# Altium schematic sheet 4.BMI055.SchDoc(4.BMI055)
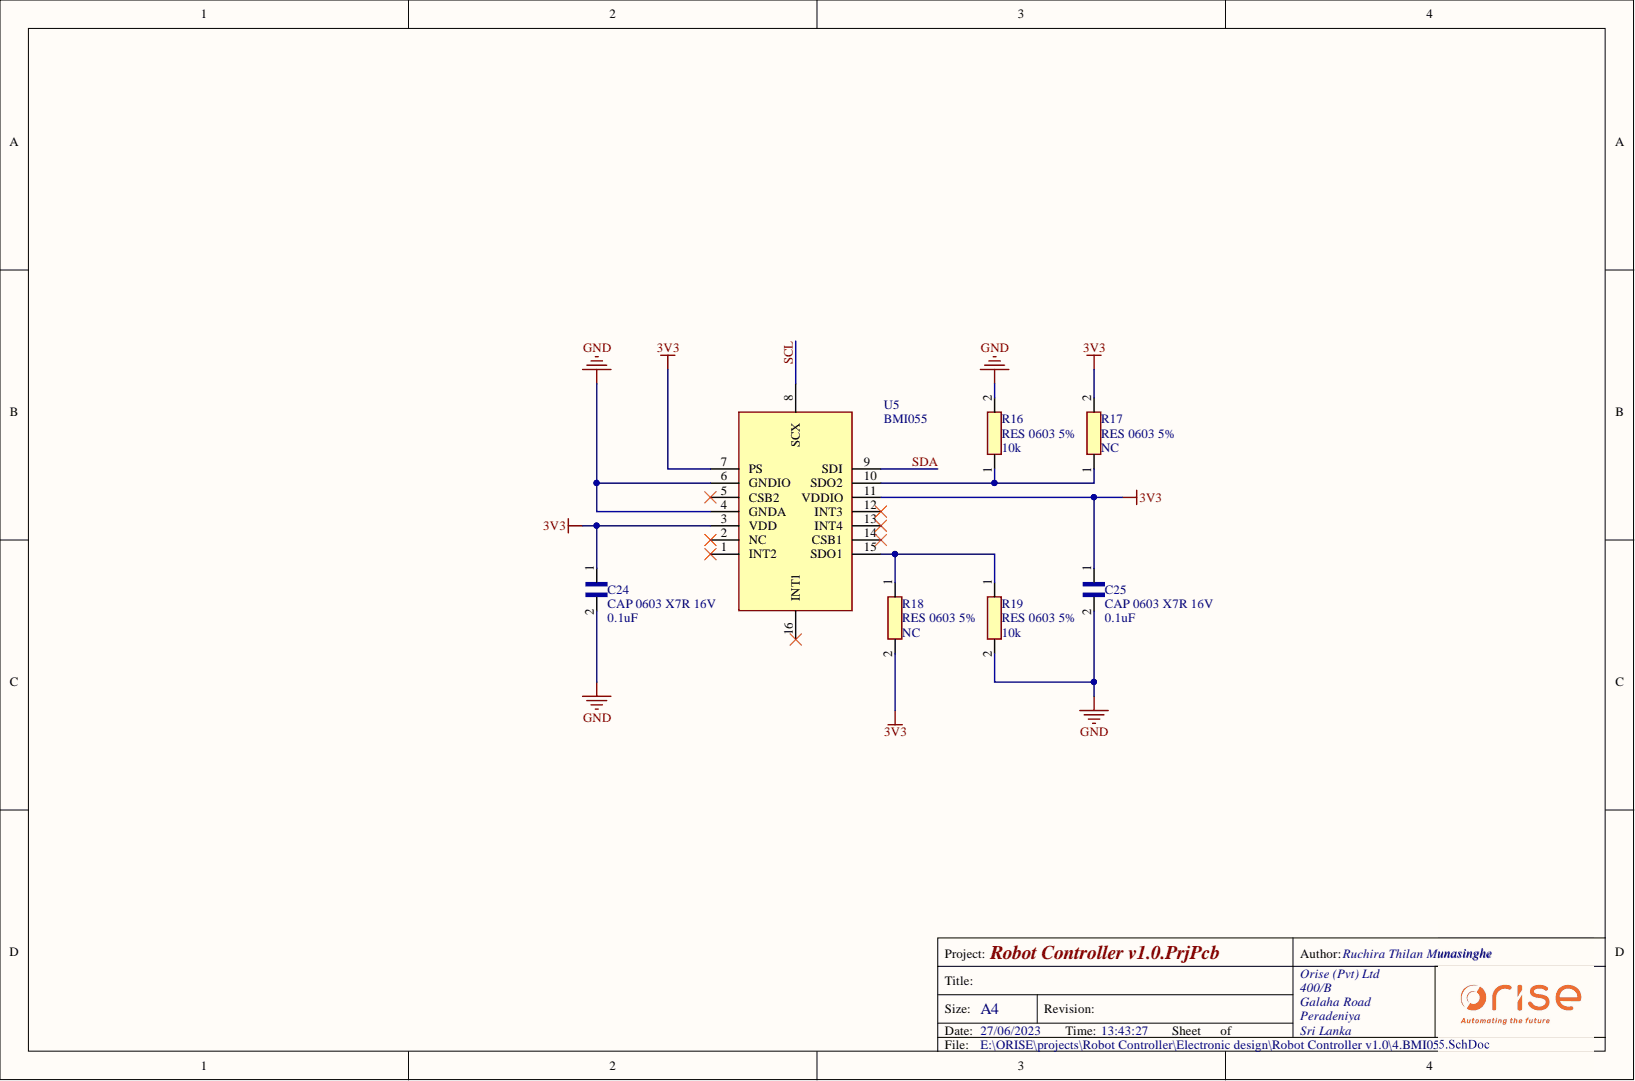
[diagram p0: 4.BMI055.SchDoc]

## Parts
C24  CAP 0603 X7R 16V  value 0.1uF  [CAP 0603]
C25  CAP 0603 X7R 16V  value 0.1uF  [CAP 0603]
R16  RES 0603 5%  value 10k  [RES 0603]
R17  RES 0603 5%  value NC  [RES 0603 NC]
R18  RES 0603 5%  value NC  [RES 0603 NC]
R19  RES 0603 5%  value 10k  [RES 0603]
U5  BMI055  [BMI055]

## Nets
3V3: C24.1 C25.1 R17.2 R18.2 U5.3(VDD) U5.7(PS) U5.11(VDDIO)
GND: C24.2 C25.2 R16.2 R19.2 U5.4(GNDA) U5.6(GNDIO)
SCL: U5.8(SCX)
SDA: U5.9(SDI)
NetR16_1: R16.1 R17.1 U5.10(SDO2)
NetR18_1: R18.1 R19.1 U5.15(SDO1)

Not connected: U5 1(INT2) 2(NC) 5(CSB2) 12(INT3) 13(INT4) 14(CSB1) 16(INT1)

## Library data of the parts
R17 R18 = RES 0603 5% NC: Library Name: Robot Controller v1.0.SchLib; Description: RES 0603 5%; Component Kind: Standard
U5 = BMI055: Integrated Circuit; Manufacturer_Name: BOSCH; Mouser Price/Stock: https://www.mouser.co.uk/ProductDetail/Bosch-Sensortec/BMI055?qs=d72FGnIDsgRto2b9Ru3roQ%3D%3D; Mouser Part Number: 262-BMI055; Manufacturer_Part_Number: BMI055; Library Name: Robot Controller v1.0.SchLib; Datasheet Link: https://datasheet.datasheetarchive.com/originals/distributors/Datasheets-DGA1/129773.pdf; Component Kind: Standard; Arrow Price/Stock: https://www.arrow.com/en/products/bmi055/bosch; Arrow Part Number: BMI055
C24 C25 = CAP 0603 X7R 16V: Library Name: Robot Controller v1.0.SchLib; Description: CAP 0603 X7R 16V; Component Kind: Standard
R16 R19 = RES 0603 5%: Library Name: Robot Controller v1.0.SchLib; Description: RES 0603 5%; Component Kind: Standard
